# Altium schematic sheet MAIN.SchDoc(MAIN)
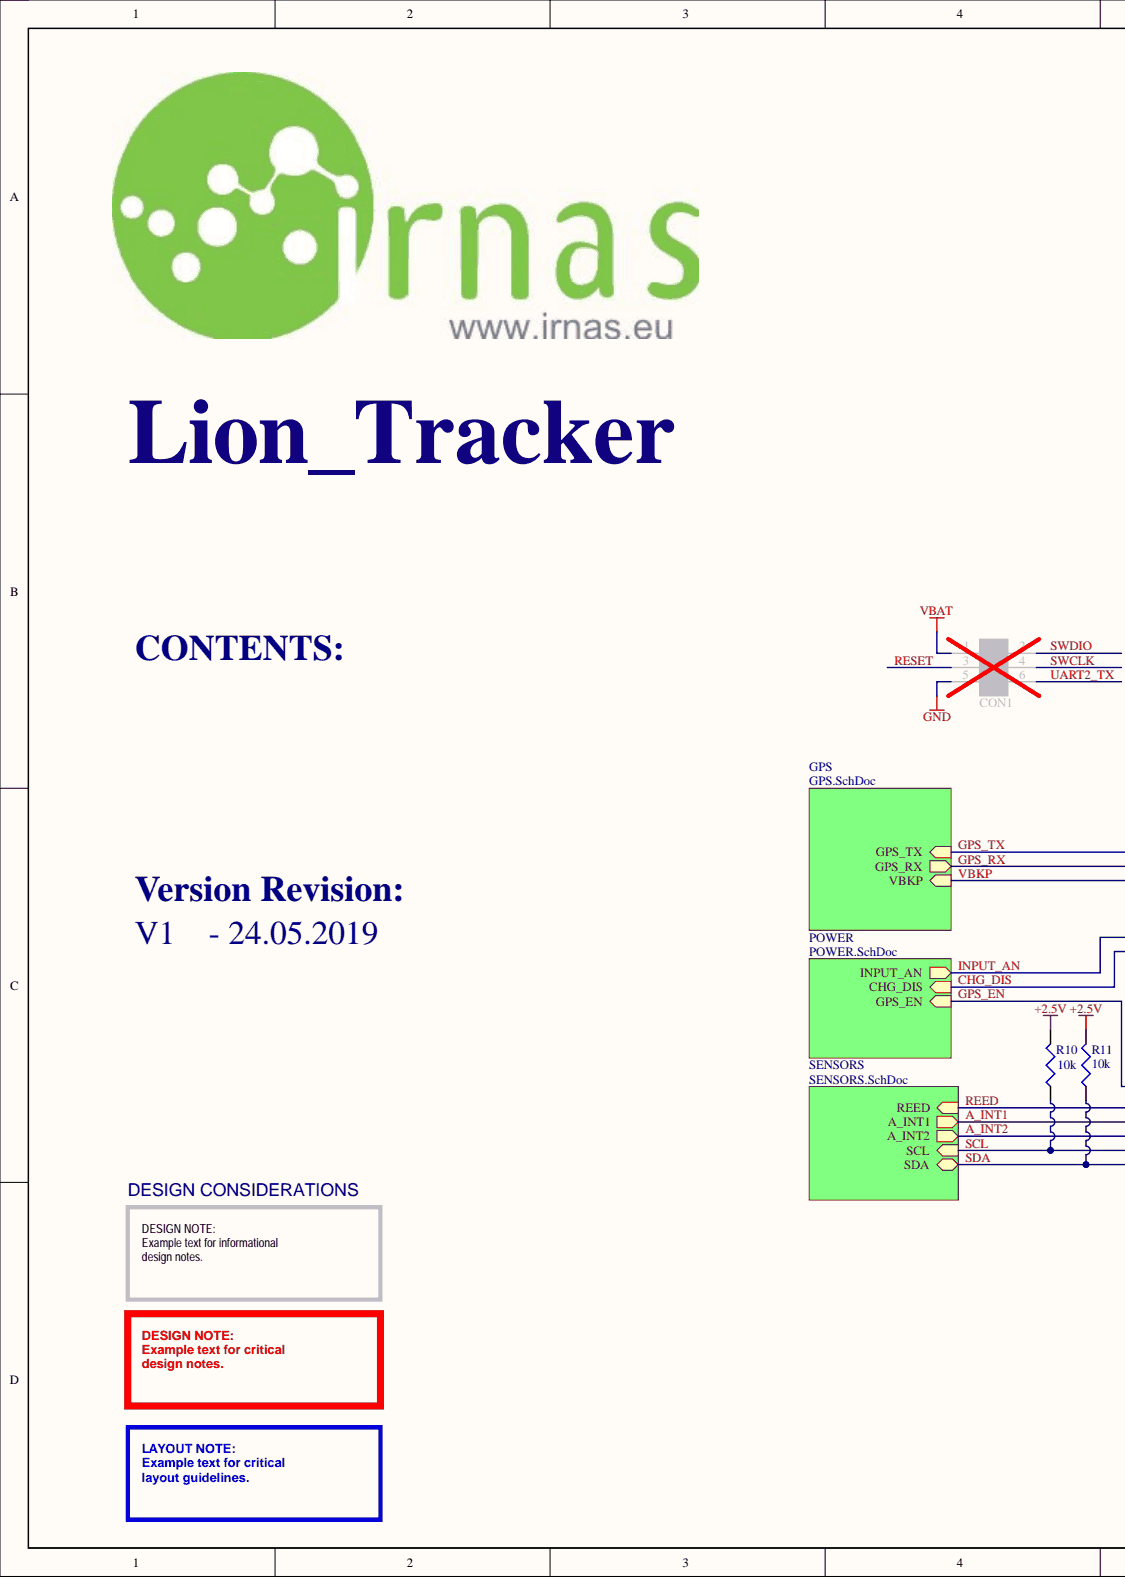
[diagram p0: MAIN.SchDoc, left]
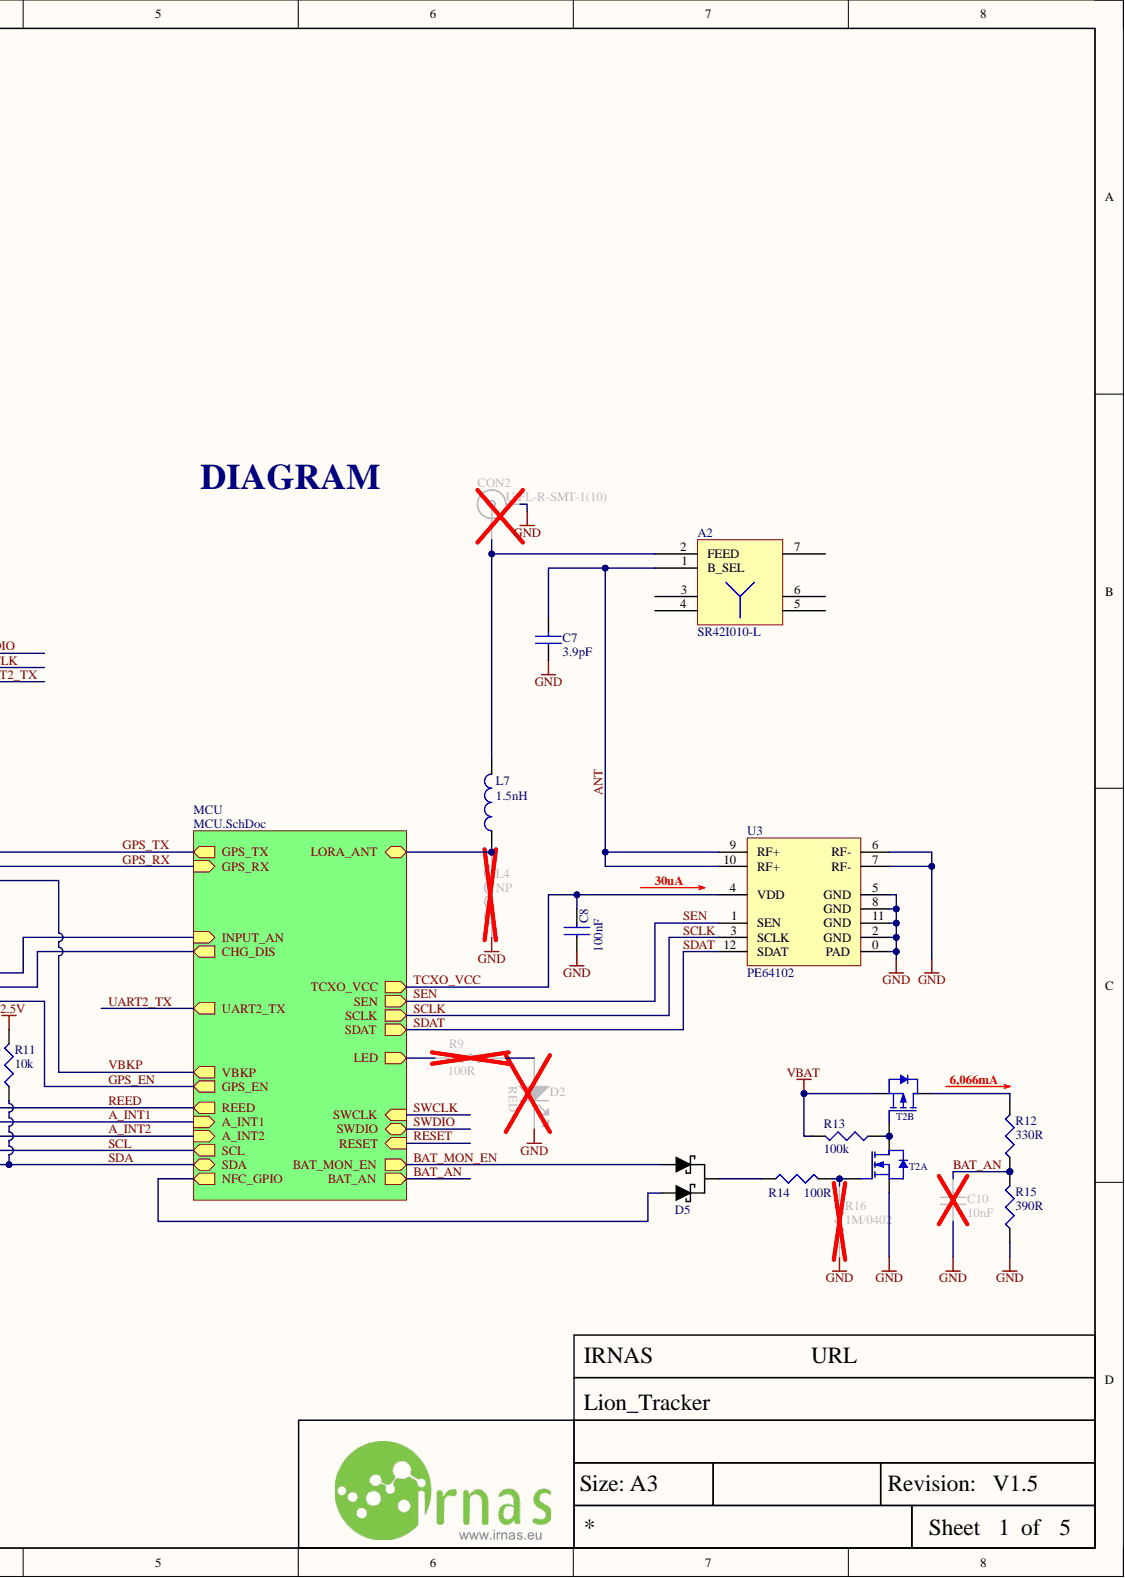
[diagram p1: MAIN.SchDoc, right]

## Parts
A2  SR42I010-L  [SR42I010-L]
C7  3.9pF  [CAP0402]
C8  100nF  [CAP0402]
C10  10nF  [CAP0402]
CON1  Tag connect  [TC2030-IDC-NL]  (value not drawn)  (no BOM)
CON2  U.FL-R-SMT-1(10)  [ufl connector smd]
D2  LED RED  [LED0603]  (value not drawn)
D5  BAS70-05,215  [SOT 23]  (value not drawn)
L4  NP  [IND0402]
L7  1.5nH  [IND0402]
R9  100R  [RES0402]
R10  10k  [RES0402]
R11  10k  [RES0402]
R12  330R  [RES0402]
R13  100k  [RES0402]
R14  100R  [RES0402]
R15  390R  [RES0402]
R16  1M/0402  [RES0402]
T2  VT6M1T2CR  [VMT6]  (value not drawn)  (parts A,B)
U3  PE64102  [QFN-12]

## Nets
+2.5V: R10.2 R11.2
GND: C7.1 C8.1 C10.1 CON1.5 CON2.2 D2.1 L4.1 R15.1 R16.1 T2.1 U3.0(PAD) U3.2(GND) U3.5(GND) U3.6(RF-) U3.7(RF-) U3.8(GND) U3.11(GND)
VBAT: CON1.1 R13.2 T2.4
ANT: A2.1(B_SEL) C7.2 U3.9(RF+) U3.10(RF+)
BAT_AN: C10.2 R12.1 R15.2
BAT_MON_EN: D5.1
RESET: CON1.3
SCL: R10.1
SCLK: U3.3(SCLK)
SDA: R11.1
SDAT: U3.12(SDAT)
SEN: U3.1(SEN)
SWCLK: CON1.4
SWDIO: CON1.2
TCXO_VCC: C8.2 U3.4(VDD)
UART2_TX: CON1.6
NetA2_2: A2.2(FEED) CON2.1 L7.2
NetA2_3: A2.3
NetA2_4: A2.4
NetA2_5: A2.5
NetA2_6: A2.6
NetA2_7: A2.7
NetD2_2: D2.2 R9.2
NetD5_2: D5.2
NetD5_3: D5.3 R14.1
NetL4_2: L4.2 L7.1
NetR9_1: R9.1
NetR12_2: R12.2 T2.3
NetR13_1: R13.1 T2.5 T2.6
NetR14_2: R14.2 R16.2 T2.2
A_INT1:
A_INT2:
CHG_DIS:
GPS_EN:
GPS_RX:
GPS_TX:
INPUT_AN:
REED:
VBKP:

## Library data of the parts
A2 = ANT - SR42I010-L: Antenna SMD -4dBi Gain 870MHz/928MHz Automotive 7-Pin SMD T/R; Manufacturer: Antenova; Manufacturer Part Number: SR42I010-R; Component Kind: Standard; Library Name: Passive.SchLib; Pricing 1: 1 = 2.36000, 10 = 1.90000, 50 = 1.90000, 100 = 1.52000, 1000 = 1.07000, 10000 = 0.95900 (USD); Stock 1: 3154; Supplier 1: Mouser; Supplier Part Number 1: 237-SR42I010-L
C7 = C - 3.9pF/0402: 3.9 pF, 50 V, 0402 [1005 Metric], ± 0.25pF, NP0; IRNAS Part No.: /; Manufacturer: KEMET; Manufacturer Part Number: MC0402N3R9C500CT; Capacitance: 1.8pF; Dielectric Material: Ceramic; Voltage Rating: 50V; Case Code (Imperial): 0402; Case Code (Metric): 1005; Case/Package: 0402; Component Kind: Standard; ComponentLink1Description: Datasheet; ComponentLink1URL: http://www.farnell.com/datasheets/2861120.pdf?_ga=2.234267230.1320867293.1574405148-1373726713.1554121096&_gac=1.121381498.1571402529.CjwKCAjwxaXtBRBbEiwAPqPxcCedmxiKa04iNyErqeZ3YE_megEuFQhz7mFuJRxR0uEYBmhYeHxt1xoC4MkQAvD_BwE; Lead Free: Lead Free; Library Name: Passive.SchLib; Max Operating Temperature: 125°C; Min Operating Temperature: -55°C; Packaging: Tape and Reel; Pricing 1: 10=0.463, 100=0.248, 500=0.182, 2500=0.153, 5000=0.134, 10000=0.11, 50000=0.0961 (EUR); Stock 1: 8950; Supplier 1: Farnell; Supplier Part Number 1: 2627407; Termination: SMD/SMT; Voltage Rating (DC): 50V
C8 = C - 100nF/0402: 0.1 F, 16 V,  5%, X5R, MC Series; IRNAS Part No.: /; Manufacturer: Multicomp; Manufacturer Part Number: MC0402X104J160CT; Voltage Rating: 16V; Capacitance: 100nF; Case Code (Imperial): 0402; Case Code (Metric): 1005; Component Kind: Standard; ComponentLink1Description: http://www.farnell.com/datasheets/2117933.pdf; ComponentLink1URL: http://www.farnell.com/datasheets/2117933.pdf; ComponentLink2Description: http://www.farnell.com/datasheets/2117900.pdf; ComponentLink2URL: http://www.farnell.com/datasheets/2117900.pdf; Dielectric: X5R; Library Name: Passive.SchLib; Max Operating Temperature: 85°C; Min Operating Temperature: -55°C; Packaging: Tape and Reel; Pricing 1: 10=0.0139, 100=0.0108, 500=0.0076, 2500=0.0051, 5000=0.0038 (EUR); REACH SVHC: No SVHC; Stock 1: 3230; Supplier 1: Farnell; Supplier Part Number 1: 2627419; Tolerance: 5%; Voltage Rating (DC): 16V
C10 = C - 10nF/0402: CAP CER 10000PF 16V X7R 0402; IRNAS Part No.: /; Manufacturer: Murata; Manufacturer Part Number: GRM155R71C103KA01D; Tolerance: 10%; Capacitance: 10nF; Case Code (Imperial): 0402; Case Code (Metric): 1005; Case/Package: 0402; Component Kind: Standard; ComponentLink1Description: https://datasheet.ciiva.com/129/partnumbering-e-01-129774.pdf; ComponentLink1URL: https://datasheet.ciiva.com/129/partnumbering-e-01-129774.pdf; ComponentLink2Description: https://datasheet.ciiva.com/129/partnumbering-e-01-129775.pdf; ComponentLink2URL: https://datasheet.ciiva.com/129/partnumbering-e-01-129775.pdf; ComponentLink3Description: https://datasheet.ciiva.com/129/product-129776.pdf; ComponentLink3URL: https://datasheet.ciiva.com/129/product-129776.pdf; ComponentLink4Description: https://datasheet.ciiva.com/18184/getdatasheetpartid-178863-18184649.pdf; ComponentLink4URL: https://datasheet.ciiva.com/18184/getdatasheetpartid-178863-18184649.pdf; ComponentLink5Description: https://datasheet.ciiva.com/22698/608985-22698415.pdf; ComponentLink5URL: https://datasheet.ciiva.com/22698/608985-22698415.pdf; ComponentLink6Description: https://datasheet.ciiva.com/7937/608985-7937614.pdf; ComponentLink6URL: https://datasheet.ciiva.com/7937/608985-7937614.pdf; Depth: 0.5mm; Dielectric: X7R; Height: 0.5mm; Lead Free: Lead Free; Length: 1mm; Library Name: Passive.SchLib; Max Operating Temperature: 125°C; Min Operating Temperature: -55°C; Mount: Surface Mount; Number of Pins: 2; Package Quantity: 1; Packaging: Tape and Reel; Pricing 1: 10=0.0216, 100=0.0151, 500=0.0104, 2500=0.0069, 5000=0.0056 (EUR); Radiation Hardening: No; REACH SVHC: No SVHC; Stock 1: 16478; Supplier 1: Farnell; Supplier Part Number 1: 1828864; Termination: SMD/SMT; Thickness: 0.022inch; Voltage: 16V; Voltage Rating: 16V; Voltage Rating (DC): 16V; Width: 0.02inch
CON1 = tag connecot 6 pin: Library Name: Connectors.SchLib
CON2 = U.FL-R-SMT-1(10): RF / Coaxial Connector, U.FL Coaxial, Straight Jack, Surface Mount Vertical, 50 ohm, Brass; Manufacturer: Hirose; Body Finish: Silver; Body Material: Phosphor Bronze; Center Contact Plating: Gold; Connector Style: U.FL, Ultra Miniature Coaxial; Connector Type: Receptacle, Male Pin; Contact Termination: Solder; Dielectric Material: Liquid Crystal Polymer (LCP); Featured Product: U.FL Series Connectors; Frequency - Max: 6GHz; Impedance: 50 Ohm; Online Catalog: UMCC (Ultra Miniature Coax Connectors), U.FL Series; Other Names: H11891TR  Q5400621  U.FL-R-SMT-1 10  U.FL-R-SMT-1(10)-ND  UFLRSMT110; Cable Group: -; Category: Connectors, Interconnects; Center Contact Material: Brass; Component Kind: Standard; ComponentLink1Description: http://www.farnell.com/datasheets/91524.pdf; ComponentLink1URL: http://www.farnell.com/datasheets/91524.pdf; ComponentLink2Description: http://uk.rs-online.com/webdocs/1363/0900766b81363563.pdf; ComponentLink2URL: http://uk.rs-online.com/webdocs/1363/0900766b81363563.pdf; ComponentLink3Description: http://www.farnell.com/datasheets/1947917.pdf; ComponentLink3URL: http://www.farnell.com/datasheets/1947917.pdf; Family: Coaxial Connectors (RF); Fastening Type: Snap-On; Features: -; Housing Color: Beige; Ingress Protection: -; Library Name: Connectors.SchLib; Manufacturer Part Number: U.FL-R-SMT-1(10); Mounting Type: Surface Mount; Operating Temperature: -40°C ~ 90°C; Packaging: Tape & Reel (TR); Series: U.FL; Shield Termination: Solder; Standard Package: 2,500; Stock 1: 0; Supplier 1: Farnell; Supplier Part Number 1: 1688077; Voltage Rating: -
D2 = LED - LED RED: BROADCOM LIMITED - HSMS-C190 - LED, RED, 10MCD, 626NM; Forward Current If: 20; Forward Voltage: 2.1; LED Mounting: SMD; Lens Shape: Rectangular; Luminous Intensity: 10; SVHC: No SVHC (17-Dec-2015)<!--FANDB--><div class="features-and-benefits-container"><div class="features-and-benefits-description"><span class="features-benefits-value">The HSMS-C190 is a HER SMD Chip LED with untinted/diffused lens, made of GaP. It is designed in an industry standard package 1.6 x 0.8mm footprint with 0.8mm profile. It is compatible with IR reflow solder processes. The small size and wide viewing angle make this LED prime choices for backlighting applications and front panel illumination especially where space is a premium.</span></div><div class="features-and-benefits-list"><ul class="features-and-benefits-list-list"><li class="features-and-benefits-list-item">Small size</li><li class="features-and-benefits-list-item">Industry standard footprint</li><li class="features-and-benefits-list-item">Compatible with IR solder</li><li class="features-and-benefits-list-item">Diffused optics</li><li class="features-and-benefits-list-item">-40 to 85; Viewing Angle: 170°; Wavelength Typ: 626; Automotive Qualification Standard: -; Bulb Size: -; Component Kind: Standard; ComponentLink1Description: http://www.farnell.com/datasheets/313031.pdf; ComponentLink1URL: http://www.farnell.com/datasheets/313031.pdf; ComponentLink2Description: http://www.farnell.com/datasheets/1681524.pdf; ComponentLink2URL: http://www.farnell.com/datasheets/1681524.pdf; ComponentLink3Description: http://www.farnell.com/datasheets/2165483.pdf; ComponentLink3URL: http://www.farnell.com/datasheets/2165483.pdf; LED Colour: Red; Library Name: Active.SchLib; Manufacturer: BROADCOM LIMITED; Manufacturer Part Number: HSMS-C190; MSL: -; Packaging: Cut Tape; Pricing 1: 1=0.361, 10=0.256, 50=0.235, 100=0.106, 200=0.101 (GBP); Product Range: -; RoHS: YES; Stock 1: 7534; Supplier 1: Farnell; Supplier Part Number 1: 2497356
D5 = DS - BAS40-05: Small Signal Schottky Diode, Dual Common Cathode, 70 V, 70 mA, 410 mV, 100 mA, 150 °C; Component Kind: Standard; ComponentLink1Description: Datasheet; ComponentLink1URL: https://eu.mouser.com/datasheet/2/916/BAS40_1PSXXSB4X_SER-1598244.pdf; Library Name: Active.SchLib; Supplier 1: Farnell; Supplier Part Number 1: 1081186
L4 = L - 4.3nH/0402: Inductor RF Chip Multi-Layer 4.3nH 0.3nH 100MHz 8Q-Factor Air 300mA 210mOhm DCR 0402 Paper T/R; IRNAS Part No.: /; Manufacturer: Murata; Manufacturer Part Number: LQG15HN4N3S02D; DC Resistance (DCR): 210mR; Q Factor: 8; Self Resonant Frequency: 6GHz; Shielding: Unshielded; Case Code (Imperial): 0402; Case Code (Metric): 1005; Case/Package: 0402; Component Kind: Standard; ComponentLink1Description: http://uk.rs-online.com/webdocs/0f10/0900766b80f10808.pdf?src-supplier=RSComponents; ComponentLink1URL: http://uk.rs-online.com/webdocs/0f10/0900766b80f10808.pdf?src-supplier=RSComponents; ComponentLink2Description: http://search.murata.co.jp/Ceramy/image/img/PDF/ENG/L0075S0083LQG15HN.pdf?src-supplier=Avnet; ComponentLink2URL: http://search.murata.co.jp/Ceramy/image/img/PDF/ENG/L0075S0083LQG15HN.pdf?src-supplier=Avnet; ComponentLink3Description: http://www.farnell.com/datasheets/66026.pdf?src-supplier=Element14; ComponentLink3URL: http://www.farnell.com/datasheets/66026.pdf?src-supplier=Element14; ComponentLink4Description: https://www.verical.com/server-webapp/html/getDatasheet?partID=31252&src-supplier=Verical; ComponentLink4URL: https://www.verical.com/server-webapp/html/getDatasheet?partID=31252&src-supplier=Verical; ComponentLink5Description: http://search.murata.co.jp/Ceramy/image/img/PDF/ENG/L0075S0083LQG15HN.pdf?src-supplier=Avnet+Europe; ComponentLink5URL: http://search.murata.co.jp/Ceramy/image/img/PDF/ENG/L0075S0083LQG15HN.pdf?src-supplier=Avnet+Europe; ComponentLink6Description: https://search.murata.co.jp/Ceramy/image/img/P02/partnumberingAT_LQ3E.pdf?src-supplier=Digi-Key; ComponentLink6URL: https://search.murata.co.jp/Ceramy/image/img/P02/partnumberingAT_LQ3E.pdf?src-supplier=Digi-Key; Core Material: Air; Current: 300mA; Current Rating: 300mA; DC Current: 300mA; Depth: 0.55mm; Height: 0.5mm; Height - Seated (Max): 0.022inch; Inductance: 4.3nh; Lead Free: Lead Free; Length: 1.05mm; Library Name: Passive.SchLib; Max DC Current: 300mA; Max Operating Temperature: 125°C; Military Standard: Not; Min Operating Temperature: -55°C; Mount: Surface Mount; Package Quantity: 10000; Packaging: Tape and Reel; Pricing 1: 1 = 0.10000, 10 = 0.06100, 100 = 0.04040, 500 = 0.03366, 1000 = 0.02827, 2500 = 0.02423 (USD); Radiation Hardening: No; REACH SVHC: No SVHC; RoHS: Yes; Stock 1: 3502; Supplier 1: Farnell; Supplier Part Number 1: 1711735; Termination: SMD/SMT; Test Frequency: 100MHz; Width: 0.02inch
L7 = L - 1.5nH/0402: 1.5 nH, LQG15HS_02 Series, 1 A, 0402; IRNAS Part No.: /; Manufacturer: Murata; Manufacturer Part Number: LQG15HS1N5S02D; Core Material: Air; Current: 300mA; DC Resistance (DCR): 210mR; Inductance: 4.3nh; Q Factor: 8; Self Resonant Frequency: 6GHz; Shielding: Unshielded; Test Frequency: 100MHz; Case Code (Imperial): 0402; Case Code (Metric): 1005; Case/Package: 0402; Component Kind: Standard; ComponentLink6Description: Datasheet; ComponentLink6URL: http://www.farnell.com/datasheets/2575533.pdf?_ga=2.129788748.1320867293.1574405148-1373726713.1554121096&_gac=1.24194632.1571402529.CjwKCAjwxaXtBRBbEiwAPqPxcCedmxiKa04iNyErqeZ3YE_megEuFQhz7mFuJRxR0uEYBmhYeHxt1xoC4MkQAvD_BwE; Current Rating: 300mA; DC Current: 300mA; Depth: 0.55mm; Height: 0.5mm; Height - Seated (Max): 0.022inch; Lead Free: Lead Free; Length: 1.05mm; Library Name: Passive.SchLib; Max DC Current: 300mA; Max Operating Temperature: 125°C; Military Standard: Not; Min Operating Temperature: -55°C; Mount: Surface Mount; Package Quantity: 10000; Packaging: Tape and Reel; Pricing 1: 1 = 0.10000, 10 = 0.06100, 100 = 0.04040, 500 = 0.03366, 1000 = 0.02827, 2500 = 0.02423 (USD); Radiation Hardening: No; REACH SVHC: No SVHC; RoHS: Yes; Stock 1: 3502; Supplier 1: Farnell; Supplier Part Number 1: 2840113; Termination: SMD/SMT; Width: 0.02inch
R9 R14 = R - 100R/0402: 100 ohm, 50 V, 0402 [1005 Metric], 62.5 mW,  1%; IRNAS Part No.: /; Manufacturer: Multicomp; Manufacturer Part Number: MCMR04X1000FTL; Power Rating: 62.5mW; Temperature Coefficient: 100ppm/°C; Voltage Rating: 50V; Case Code (Imperial): 0402; Case Code (Metric): 1005; Case/Package: 0402; Component Kind: Standard; ComponentLink1Description: https://datasheet.ciiva.com/28692/1716705-28692087.pdf; ComponentLink1URL: https://datasheet.ciiva.com/28692/1716705-28692087.pdf; ComponentLink2Description: https://datasheet.ciiva.com/22708/1716705-22708156.pdf; ComponentLink2URL: https://datasheet.ciiva.com/22708/1716705-22708156.pdf; Composition: Ceramic; Library Name: Passive.SchLib; Packaging: Cut Tape; Pricing 1: 10=0.0071, 100=0.0042, 500=0.003, 2500=0.0024, 5000=0.0019 (GBP); REACH SVHC: No SVHC; Resistance: 100R; Stock 1: 62365; Supplier 1: Farnell; Supplier Part Number 1: 2072515; Tolerance: 1%; Voltage Rating (DC): 50V
R10 R11 = R - 10k/0402: 10 kohm, 50 V, 0402 [1005 Metric], 62.5 mW,  1%, MCWR Series; IRNAS Part No.: I-100014; Manufacturer: Multicomp; Manufacturer Part Number: MCWR04X1002FTL; Power Rating: 62.5mW; Resistance: 10kR; Temperature Coefficient: 100ppm/°C; Voltage Rating: 50V; Case Code (Imperial): 0402; Case Code (Metric): 1005; Case/Package: 0402; Component Kind: Standard; ComponentLink1Description: http://www.farnell.com/datasheets/1849611.pdf; ComponentLink1URL: http://www.farnell.com/datasheets/1849611.pdf; Composition: Thick Film; Library Name: Passive.SchLib; Packaging: Cut Tape; Pricing 1: 10=0.0029, 100=0.0017, 500=0.0012, 2500=0.001, 5000=0.0008 (EUR); REACH SVHC: No SVHC; Stock 1: 602609; Supplier 1: Farnell; Supplier Part Number 1: 2447096; Tolerance: 1%; Voltage Rating (DC): 50V
R12 = R - 330R/0402: MULTICOMP   MCMR04X3300FTL   Surface Mount Chip Resistor, Ceramic, MCMR Series, 330 ohm, 62.5 mW,  1%, 50 V, 0402 [1005 Metric]; IRNAS Part No.: /; Power Rating: 62.5mW; Temperature Coefficient: 100ppm/°C; Voltage Rating: 50V; Case Code (Imperial): 0402; Case Code (Metric): 1005; Case/Package: 0402; Component Kind: Standard; ComponentLink1Description: https://datasheet.ciiva.com/28673/1716706-28673288.pdf; ComponentLink1URL: https://datasheet.ciiva.com/28673/1716706-28673288.pdf; Composition: Ceramic; Library Name: Passive.SchLib; Manufacturer: Multicomp; Manufacturer Part Number: MCMR04X3300FTL; Packaging: Cut Tape; Pricing 1: 10000=0.0015, 50000=0.0013 (EUR); REACH SVHC: No SVHC; Resistance: 330R; Stock 1: 20000; Supplier 1: Farnell; Supplier Part Number 1: 2692084; Tolerance: 1%; Voltage Rating (DC): 50V
R13 = R - 100k/0402: 100KOHM, 1%, 0.0625W; IRNAS Part No.: I-100013; Manufacturer: Multicomp; Manufacturer Part Number: MCWR04X1003FTL; Power Rating: 62.5mW; Resistance: 100kR; Temperature Coefficient: 100ppm/°C; Voltage Rating: 50V; Case Code (Imperial): 0402; Case Code (Metric): 1005; Case/Package: 0402; Component Kind: Standard; ComponentLink1Description: https://datasheet.ciiva.com/46386/1849611-46386524.pdf; ComponentLink1URL: https://datasheet.ciiva.com/46386/1849611-46386524.pdf; Composition: Thick Film; Library Name: Passive.SchLib; Mount: Surface Mount; Packaging: Cut Tape; Pricing 1: 10=0.0029, 100=0.0017, 500=0.0012, 2500=0.001, 5000=0.0008 (EUR); REACH SVHC: No SVHC; Stock 1: 161188; Supplier 1: Farnell; Supplier Part Number 1: 2447094; Tolerance: 1%; Voltage Rating (DC): 50V
R15 = R - 390R/0402: 390 ohm, MCWR Series, 50 V, Thick Film, 62.5 mW; IRNAS Part No.: /; Manufacturer: Multicomp; Manufacturer Part Number: 390/0402; Power Rating: 62.5mW; Temperature Coefficient: 400ppm/°C; Voltage Rating: 50V; Case Code (Imperial): 0402; Case Code (Metric): 1005; Case/Package: 0402; Component Kind: Standard; ComponentLink1Description: Datasheet; ComponentLink1URL: http://www.farnell.com/datasheets/2563624.pdf?_ga=2.57959210.1822379758.1564985606-1373726713.1554121096&_gac=1.48955732.1562763802.CjwKCAjwmZbpBRAGEiwADrmVXm8xT8OHDZvJe8qZIQyyGmIuiUD-TEITatw0hIdDkyBwIXXCH7oneRoCl7cQAvD_BwE; Composition: Ceramic; Library Name: Passive.SchLib; Packaging: Cut Tape; Pricing 1: 10=0.0057, 100=0.0034, 500=0.0024, 2500=0.0019, 5000=0.0015 (EUR); REACH SVHC: No SVHC; Resistance: 390R; Stock 1: 0; Supplier 1: Farnell; Supplier Part Number 1: 2447165; Voltage Rating (DC): 50V
R16 = R - 1M/0402: MCMR04X1004FTL RES, 1M, 1%, 0.0625W, 0402, THICK FILM; IRNAS Part No.: I-100012; Manufacturer: MULTICOMP; Manufacturer Part Number: MC0063W060311M; External Depth: 0.45; External Length / Height: 1.6; External Width: 0.8; No. of Pins: 2; Power Rating: 63; Product Range: MC Series; Reel Quantity: 5000; Resistance: 1; Resistor Case Style: 0603 [1608 Metric]; Resistor Mounting: Surface Mount Device; SVHC: No SVHC (17-Dec-2015); Temperature Coeff. +: 100; Temperature Coefficient: ± 100; Voltage Rating: 50; Voltage Rating V DC: 50V<!--FANDB--><div class="features-and-benefits-container"><div class="features-and-benefits-description"><span class="features-benefits-value">The Multicomp MC series current sense resistors are thick film chip resistors. The resistance range includes E96 and E24 decade values from 1ohm to 10Mohm.</span></div><div class="features-and-benefits-list"><ul class="features-and-benefits-list-list"><li class="features-and-benefits-list-item">EIA 0402, 0603, 0805, 1206 chip sizes available</li><li class="features-and-benefits-list-item">Small size and low weight</li><li class="features-and-benefits-list-item">Suitable for both wave and reflow soldering</li><li class="features-and-benefits-list-item">Reduction of assembly costs</li><li class="features-and-benefits-list-item">Maximum working voltage of 50V(0402, 0603), 150V(0805), 200V(1206)</li><li class="features-and-benefits-list-item">Available in ±1%, ±2% and ±5% tolerance</li><li class="features-and-benefits-list-item">Power rating of 62.5mW, 100mW and 125mW</li><li class="features-and-benefits-list-item">±400ppm/°C(1ohm to 10ohm), ±200ppm/°C(11ohm to 100ohm), ±100; Automotive Qualification Standard: -; Component Kind: Standard; ComponentLink1Description: http://www.farnell.com/datasheets/1788326.pdf; ComponentLink1URL: http://www.farnell.com/datasheets/1788326.pdf; ComponentLink2Description: http://www.farnell.com/cad/1558928.zip; ComponentLink2URL: http://www.farnell.com/cad/1558928.zip; Library Name: Passive.SchLib; Operating Temperature Max: 155; Operating Temperature Min: -55; Operating Temperature Range: -55°C to +155; Packaging: Cut Tape; Pricing 1: 10=0.0011, 100=0.001, 500=0.0009, 1000=0.0008, 2500=0.0007 (GBP); Resistance Tolerance: ± 1%; Resistor Element Material: Thick Film; RoHS: Y-EX; Stock 1: 333415; Supplier 1: Farnell; Supplier Part Number 1: 9330410; Tape Width: 8; Temperature Coeff. -: 100; Tolerance +: 1%; Tolerance -: 1%
T2 = T_M - VT6M1T2CR: Dual MOSFET, N and P Channel, 100 mA, 20 V, 2.5 ohm, 4.5 V, 1 V; ELRAD Id sifra / ELRAD Id No: 597778; FIT: 0.44; Komp / Device: SMD; Opis / Description: MOSFET BSS123       /N-Channel; Value: BSS123; Velikost / Size: SOT23; Component Kind: Standard; ComponentLINK1Description: Datasheet; ComponentLINK2Description: Datasheet www; ComponentLINK2URL: http://www.onsemi.com/pub/Collateral/BSS123-D.PDF; Date: 11.07.2018; Library Name: Active.SchLib; Manufacturer Part Number: VT6M1T2CR; Supplier 1: Farnell; Supplier Part Number 1: 2706721; Author: Ciril Golob; ComponentLINK1URL: c:\ELRADSVN\Altium_Library\Libraries\Active\Datasheet\BSS123.pdf
U3 = RF - PE64102: RF IC Digitally Tunable Capacitor General Purpose 100MHz ~ 3GHz SPI Interface 12-QFN (2x2); Manufacturer: u-blox; Manufacturer Part Number: MAX-M8Q-0; Component Kind: Standard; Library Name: Active.SchLib; Stock 1: 10805; Supplier 1: Digi-Key; Supplier Part Number 1: 1046-1066-1-ND
